# Altium schematic sheet XTIO.SchDoc
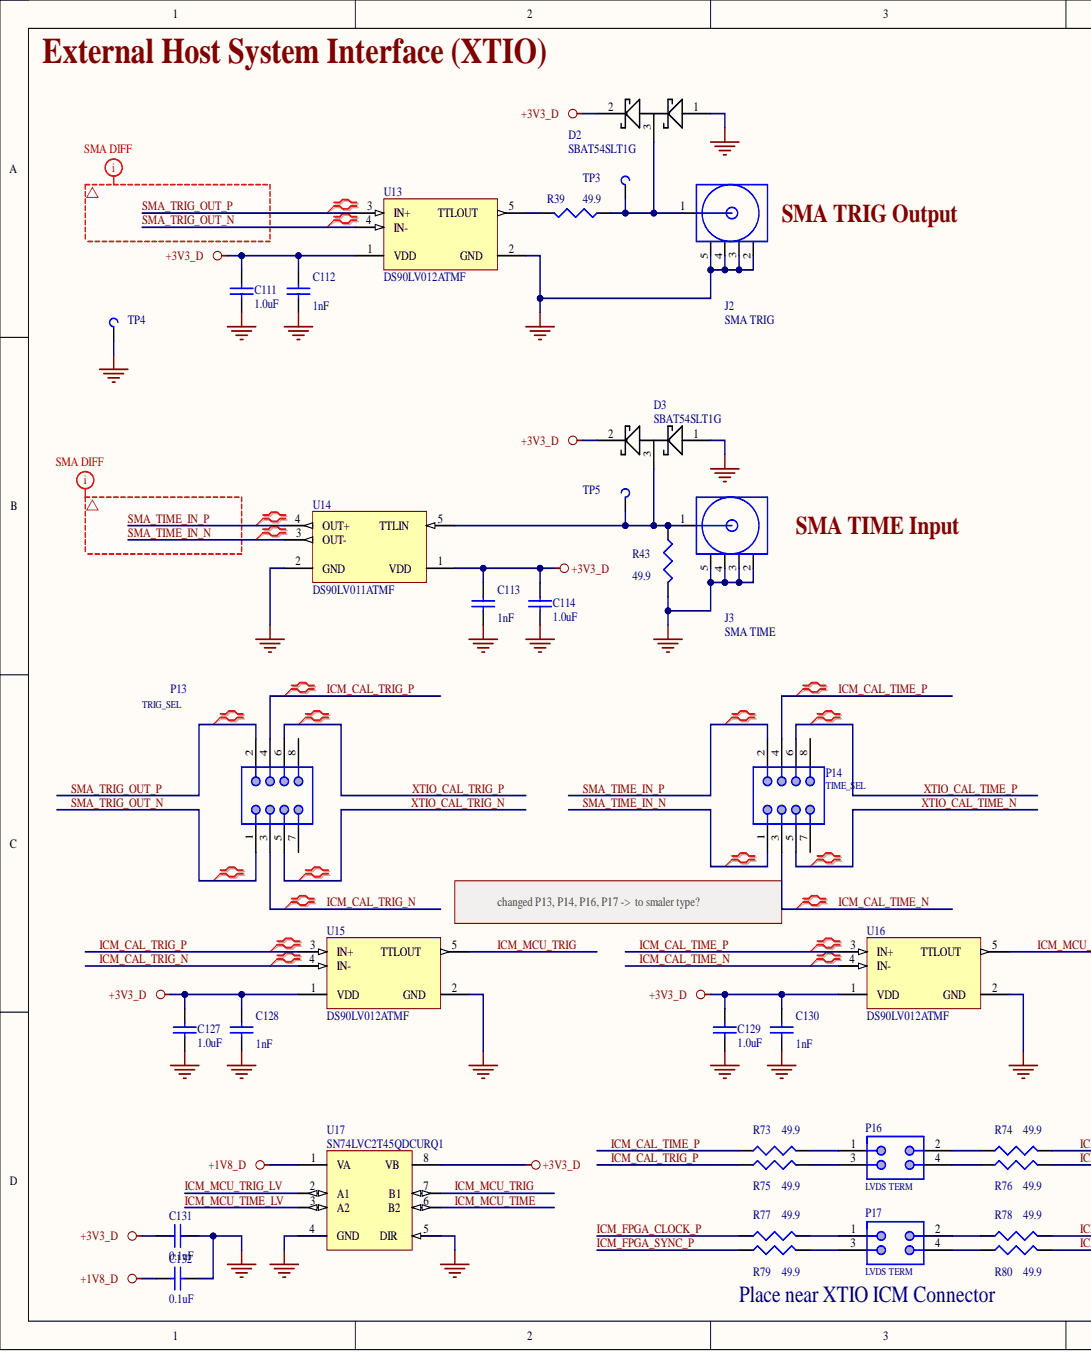
[diagram p0: XTIO.SchDoc, left]
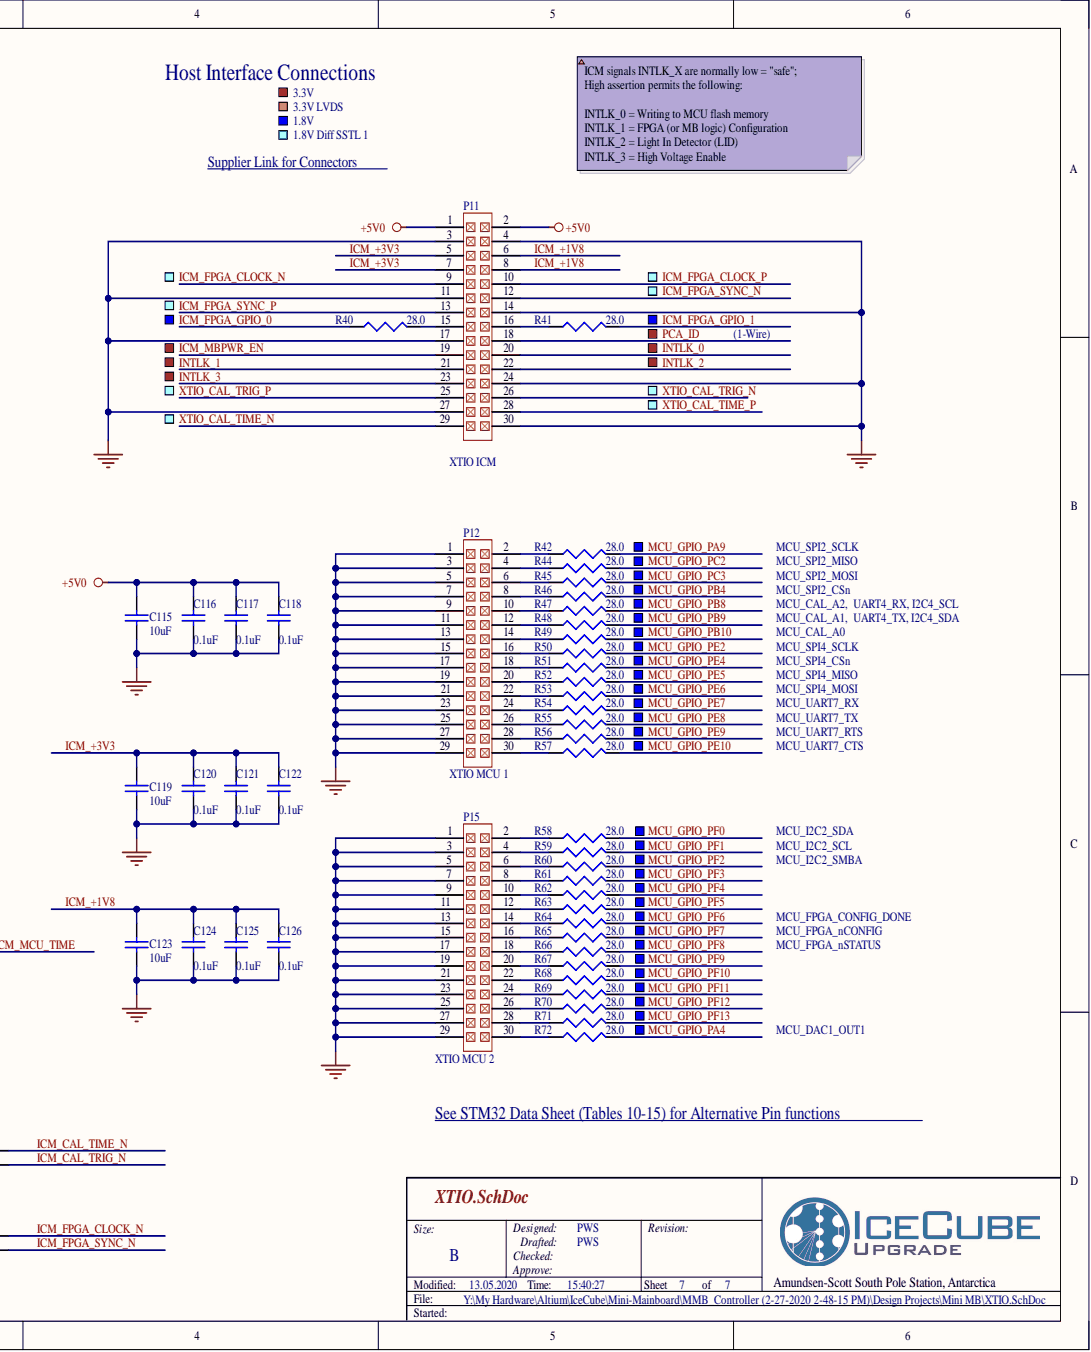
[diagram p1: XTIO.SchDoc, right]

## Parts
C111  Capacitance: 1.0uF  [CAPC_0603]  (hidden comment: 1.0uF_603_25V)
C112  Capacitance: 1nF  [CAPC_0603]  (hidden comment: 1000pF_603_50V)
C113  Capacitance: 1nF  [CAPC_0603]  (hidden comment: 1000pF_603_50V)
C114  Capacitance: 1.0uF  [CAPC_0603]  (hidden comment: 1.0uF_603_25V)
C115  Capacitance: 10uF  [CAPC_0805]  (hidden comment: 10uF_805_10V)
C116  Capacitance: 0.1uF  [CAPC_0603]  (hidden comment: 0.1uF_603_50V)
C117  Capacitance: 0.1uF  [CAPC_0603]  (hidden comment: 0.1uF_603_50V)
C118  Capacitance: 0.1uF  [CAPC_0603]  (hidden comment: 0.1uF_603_50V)
C119  Capacitance: 10uF  [CAPC_0805]  (hidden comment: 10uF_805_10V)
C120  Capacitance: 0.1uF  [CAPC_0603]  (hidden comment: 0.1uF_603_50V)
C121  Capacitance: 0.1uF  [CAPC_0603]  (hidden comment: 0.1uF_603_50V)
C122  Capacitance: 0.1uF  [CAPC_0603]  (hidden comment: 0.1uF_603_50V)
C123  Capacitance: 10uF  [CAPC_0805]  (hidden comment: 10uF_805_10V)
C124  Capacitance: 0.1uF  [CAPC_0603]  (hidden comment: 0.1uF_603_50V)
C125  Capacitance: 0.1uF  [CAPC_0603]  (hidden comment: 0.1uF_603_50V)
C126  Capacitance: 0.1uF  [CAPC_0603]  (hidden comment: 0.1uF_603_50V)
C127  Capacitance: 1.0uF  [CAPC_0603]  (hidden comment: 1.0uF_603_25V)
C128  Capacitance: 1nF  [CAPC_0603]  (hidden comment: 1000pF_603_50V)
C129  Capacitance: 1.0uF  [CAPC_0603]  (hidden comment: 1.0uF_603_25V)
C130  Capacitance: 1nF  [CAPC_0603]  (hidden comment: 1000pF_603_50V)
C131  Capacitance: 0.1uF  [CAPC_0603]  (hidden comment: 0.1uF_603_50V)
C132  Capacitance: 0.1uF  [CAPC_0603]  (hidden comment: 0.1uF_603_50V)
D2  SBAT54SLT1G  [SOT-23]
D3  SBAT54SLT1G  [SOT-23]
J2  SMA TRIG  Connector Style: SMA  [SMA Vertical 200LS]
J3  SMA TIME  Connector Style: SMA  [SMA Vertical 200LS]
P11  XTIO ICM  [45230-220230]
P12  XTIO MCU 1  [45230-220230]
P13  TRIG_SEL  [62100821021]
P14  TIME_SEL  [62100821021]
P15  XTIO MCU 2  [45230-220230]
P16  LVDS TERM  [62100421021]
P17  LVDS TERM  [62100421021]
R39  Resistance: 49.9  [RES_1206]  (hidden comment: R_49.9_1206_1%)
R40  Resistance: 28.0  [RES_0603]  (hidden comment: R_28.0_603_1%)
R41  Resistance: 28.0  [RES_0603]  (hidden comment: R_28.0_603_1%)
R42  Resistance: 28.0  [RES_0603]  (hidden comment: R_28.0_603_1%)
R43  Resistance: 49.9  [RES_1206]  (hidden comment: R_49.9_1206_1%)
R44  Resistance: 28.0  [RES_0603]  (hidden comment: R_28.0_603_1%)
R45  Resistance: 28.0  [RES_0603]  (hidden comment: R_28.0_603_1%)
R46  Resistance: 28.0  [RES_0603]  (hidden comment: R_28.0_603_1%)
R47  Resistance: 28.0  [RES_0603]  (hidden comment: R_28.0_603_1%)
R48  Resistance: 28.0  [RES_0603]  (hidden comment: R_28.0_603_1%)
R49  Resistance: 28.0  [RES_0603]  (hidden comment: R_28.0_603_1%)
R50  Resistance: 28.0  [RES_0603]  (hidden comment: R_28.0_603_1%)
R51  Resistance: 28.0  [RES_0603]  (hidden comment: R_28.0_603_1%)
R52  Resistance: 28.0  [RES_0603]  (hidden comment: R_28.0_603_1%)
R53  Resistance: 28.0  [RES_0603]  (hidden comment: R_28.0_603_1%)
R54  Resistance: 28.0  [RES_0603]  (hidden comment: R_28.0_603_1%)
R55  Resistance: 28.0  [RES_0603]  (hidden comment: R_28.0_603_1%)
R56  Resistance: 28.0  [RES_0603]  (hidden comment: R_28.0_603_1%)
R57  Resistance: 28.0  [RES_0603]  (hidden comment: R_28.0_603_1%)
R58  Resistance: 28.0  [RES_0603]  (hidden comment: R_28.0_603_1%)
R59  Resistance: 28.0  [RES_0603]  (hidden comment: R_28.0_603_1%)
R60  Resistance: 28.0  [RES_0603]  (hidden comment: R_28.0_603_1%)
R61  Resistance: 28.0  [RES_0603]  (hidden comment: R_28.0_603_1%)
R62  Resistance: 28.0  [RES_0603]  (hidden comment: R_28.0_603_1%)
R63  Resistance: 28.0  [RES_0603]  (hidden comment: R_28.0_603_1%)
R64  Resistance: 28.0  [RES_0603]  (hidden comment: R_28.0_603_1%)
R65  Resistance: 28.0  [RES_0603]  (hidden comment: R_28.0_603_1%)
R66  Resistance: 28.0  [RES_0603]  (hidden comment: R_28.0_603_1%)
R67  Resistance: 28.0  [RES_0603]  (hidden comment: R_28.0_603_1%)
R68  Resistance: 28.0  [RES_0603]  (hidden comment: R_28.0_603_1%)
R69  Resistance: 28.0  [RES_0603]  (hidden comment: R_28.0_603_1%)
R70  Resistance: 28.0  [RES_0603]  (hidden comment: R_28.0_603_1%)
R71  Resistance: 28.0  [RES_0603]  (hidden comment: R_28.0_603_1%)
R72  Resistance: 28.0  [RES_0603]  (hidden comment: R_28.0_603_1%)
R73  Resistance: 49.9  [RES_0603]  (hidden comment: R_49.9_603_1%)
R74  Resistance: 49.9  [RES_0603]  (hidden comment: R_49.9_603_1%)
R75  Resistance: 49.9  [RES_0603]  (hidden comment: R_49.9_603_1%)
R76  Resistance: 49.9  [RES_0603]  (hidden comment: R_49.9_603_1%)
R77  Resistance: 49.9  [RES_0603]  (hidden comment: R_49.9_603_1%)
R78  Resistance: 49.9  [RES_0603]  (hidden comment: R_49.9_603_1%)
R79  Resistance: 49.9  [RES_0603]  (hidden comment: R_49.9_603_1%)
R80  Resistance: 49.9  [RES_0603]  (hidden comment: R_49.9_603_1%)
TP3  PC TEST POINT MINI SMD  [Test Point SM]  (value not drawn)
TP4  PC TEST POINT MINI SMD  [Test Point SM]  (value not drawn)
TP5  PC TEST POINT MINI SMD  [Test Point SM]  (value not drawn)
U13  DS90LV012ATMF  [MF05A_N]
U14  DS90LV011ATMF  [MF05A_N]
U15  DS90LV012ATMF  [MF05A_N]
U16  DS90LV012ATMF  [MF05A_N]
U17  SN74LVC2T45QDCURQ1  [TI-DGK8_N]

## Nets
+1V8_D: C132.2 U17.1(VA)
+3V3_D: C111.2 C112.2 C113.2 C114.2 C127.2 C128.2 C129.2 C130.2 C131.2 D2.2 D3.2 U13.1(VDD) U14.1(VDD) U15.1(VDD) U16.1(VDD) U17.8(VB)
+5V0: C115.2 C116.2 C117.2 C118.2 P11.1 P11.2
GND: C111.1 C112.1 C113.1 C114.1 C115.1 C116.1 C117.1 C118.1 C119.1 C120.1 C121.1 C122.1 C123.1 C124.1 C125.1 C126.1 C127.1 C128.1 C129.1 C130.1 C131.1 C132.1 D2.1 D3.1 J2.2 J2.3 J2.4 J2.5 J3.2 J3.3 J3.4 J3.5 P11.3 P11.4 P11.11 P11.14 P11.17 P11.24 P11.27 P11.30 P12.1 P12.3 P12.5 P12.7 P12.9 P12.11 P12.13 P12.15 P12.17 P12.19 P12.21 P12.23 P12.25 P12.27 P12.29 P15.1 P15.3 P15.5 P15.7 P15.9 P15.11 P15.13 P15.15 P15.17 P15.19 P15.21 P15.23 P15.25 P15.27 P15.29 R43.1 TP4.1 U13.2(GND) U14.2(GND) U15.2(GND) U16.2(GND) U17.4(GND) U17.5(DIR)
ICM_+1V8: C123.2 C124.2 C125.2 C126.2 P11.6 P11.8
ICM_+3V3: C119.2 C120.2 C121.2 C122.2 P11.5 P11.7
ICM_CAL_TIME_N: P14.3 R74.2 U16.4(IN-)
ICM_CAL_TIME_P: P14.4 R73.1 U16.3(IN+)
ICM_CAL_TRIG_N: P13.3 R76.2 U15.4(IN-)
ICM_CAL_TRIG_P: P13.4 R75.1 U15.3(IN+)
ICM_FPGA_CLOCK_N: P11.9 R78.2
ICM_FPGA_CLOCK_P: P11.10 R77.1
ICM_FPGA_GPIO_0: R40.1
ICM_FPGA_GPIO_1: R41.2
ICM_FPGA_SYNC_N: P11.12 R80.2
ICM_FPGA_SYNC_P: P11.13 R79.1
ICM_MBPWR_EN: P11.19
ICM_MCU_TIME: U16.5(TTLOUT) U17.6(B2)
ICM_MCU_TIME_LV: U17.3(A2)
ICM_MCU_TRIG: U15.5(TTLOUT) U17.7(B1)
ICM_MCU_TRIG_LV: U17.2(A1)
INTLK_0: P11.20
INTLK_1: P11.21
INTLK_2: P11.22
INTLK_3: P11.23
MCU_GPIO_PA4: R72.2
MCU_GPIO_PA9: R42.2
MCU_GPIO_PB4: R46.2
MCU_GPIO_PB8: R47.2
MCU_GPIO_PB9: R48.2
MCU_GPIO_PB10: R49.2
MCU_GPIO_PC2: R44.2
MCU_GPIO_PC3: R45.2
MCU_GPIO_PE2: R50.2
MCU_GPIO_PE4: R51.2
MCU_GPIO_PE5: R52.2
MCU_GPIO_PE6: R53.2
MCU_GPIO_PE7: R54.2
MCU_GPIO_PE8: R55.2
MCU_GPIO_PE9: R56.2
MCU_GPIO_PE10: R57.2
MCU_GPIO_PF0: R58.2
MCU_GPIO_PF1: R59.2
MCU_GPIO_PF2: R60.2
MCU_GPIO_PF3: R61.2
MCU_GPIO_PF4: R62.2
MCU_GPIO_PF5: R63.2
MCU_GPIO_PF6: R64.2
MCU_GPIO_PF7: R65.2
MCU_GPIO_PF8: R66.2
MCU_GPIO_PF9: R67.2
MCU_GPIO_PF10: R68.2
MCU_GPIO_PF11: R69.2
MCU_GPIO_PF12: R70.2
MCU_GPIO_PF13: R71.2
PCA_ID: P11.18
SMA_TIME_IN_N: P14.1 U14.3(OUT-)
SMA_TIME_IN_P: P14.2 U14.4(OUT+)
SMA_TRIG_OUT_N: P13.1 U13.4(IN-)
SMA_TRIG_OUT_P: P13.2 U13.3(IN+)
XTIO_CAL_TIME_N: P11.29 P14.5
XTIO_CAL_TIME_P: P11.28 P14.6
XTIO_CAL_TRIG_N: P11.26 P13.5
XTIO_CAL_TRIG_P: P11.25 P13.6
NetD2_3: D2.3 J2.1 R39.1 TP3.1
NetD3_3: D3.3 J3.1 R43.2 TP5.1 U14.5(TTLIN)
NetP11_15: P11.15 R40.2
NetP11_16: P11.16 R41.1
NetP12_2: P12.2 R42.1
NetP12_4: P12.4 R44.1
NetP12_6: P12.6 R45.1
NetP12_8: P12.8 R46.1
NetP12_10: P12.10 R47.1
NetP12_12: P12.12 R48.1
NetP12_14: P12.14 R49.1
NetP12_16: P12.16 R50.1
NetP12_18: P12.18 R51.1
NetP12_20: P12.20 R52.1
NetP12_22: P12.22 R53.1
NetP12_24: P12.24 R54.1
NetP12_26: P12.26 R55.1
NetP12_28: P12.28 R56.1
NetP12_30: P12.30 R57.1
NetP15_2: P15.2 R58.1
NetP15_4: P15.4 R59.1
NetP15_6: P15.6 R60.1
NetP15_8: P15.8 R61.1
NetP15_10: P15.10 R62.1
NetP15_12: P15.12 R63.1
NetP15_14: P15.14 R64.1
NetP15_16: P15.16 R65.1
NetP15_18: P15.18 R66.1
NetP15_20: P15.20 R67.1
NetP15_22: P15.22 R68.1
NetP15_24: P15.24 R69.1
NetP15_26: P15.26 R70.1
NetP15_28: P15.28 R71.1
NetP15_30: P15.30 R72.1
NetP16_1: P16.1 R73.2
NetP16_2: P16.2 R74.1
NetP16_3: P16.3 R75.2
NetP16_4: P16.4 R76.1
NetP17_1: P17.1 R77.2
NetP17_2: P17.2 R78.1
NetP17_3: P17.3 R79.2
NetP17_4: P17.4 R80.1
NetR39_2: R39.2 U13.5(TTLOUT)

Not connected: P13 7 8; P14 7 8

## Library data of the parts
C111 C114 C127 C129 = CapC_1.0uF_603_25V: 1µF ±10% 25V Ceramic Capacitor X7R 0603 (1608 Metric); Manufacturer: Murata; Manufacturer Part Number: GCJ188R71E105KA01D; Case/Package: 0603; Component Kind: Standard; ComponentLink1Description: Supplier Link; ComponentLink1URL: https://www.digikey.com/products/en?keywords=490-14409-1-ND; ComponentLink2Description: Datasheet; ComponentLink2URL: https://search.murata.co.jp/Ceramy/image/img/A01X/G101/ENG/GCJ188R71E105KA01-01.pdf; ComponentLink3Description: Catalog; ComponentLink3URL: https://www.murata.com/~/media/webrenewal/support/library/catalog/products/capacitor/mlcc/c03e.ashx?la=en-us; Lead Free: Lead Free; Library Name: Custom1.SchLib; Pricing 1: 1=0.28000, 10=0.19400, 100=0.11020, 500=0.07780, 1000=0.06807 (USD); RoHS: No; Signal Integrity: Cap; Simulation: CAP; Stock 1: 166271; Supplier 1: Digi-Key; Supplier Part Number 1: 490-14409-1-ND
C112 C113 C128 C130 = CapC_1000pF_603_50V: Capacitor; Manufacturer: Knowles Syfer; Manufacturer Part Number: 0603Y0500102KXT; Dielectric: X7R; Dissipation Factor: 2.5%; Tolerance: 10%; Voltage Rating: 50V; Case Code (Imperial): 0603; Case Code (Metric): 1608; Case/Package: 0603; Component Kind: Standard; ComponentLink1Description: Datasheet; ComponentLink1URL: https://www.knowlescapacitors.com/getattachment/2dbfc8bc-e2b3-4b4d-afb1-43b011b9ebe0/High-Std-Voltage.aspx; ComponentLink2Description: Supplier Link; ComponentLink2URL: https://www.digikey.com/product-detail/en/knowles-syfer/0603Y0500102KXT/1608-1367-1-ND/7644469; Composition: Ceramic; Depth: 0.8mm; Height: 0.8mm; Length: 1.6mm; Library Name: Custom1.SchLib; Max Operating Temperature: 125°C; Min Operating Temperature: -55°C; Package Quantity: 4000; Packaging: Tape and Reel; Pricing 1: 1 = 0.540000, 10 = 0.379000, 100 = 0.249600, 500 = 0.184900, 1000 = 0.157160 (USD); RoHS: Yes; Signal Integrity: Cap; Simulation: CAP; Stock 1: 7150; Supplier 1: Digi-Key; Supplier Part Number 1: 1608-1367-1-ND; Termination: SMD/SMT; Voltage Rating (DC): 50V; Width: 0.8mm
C115 C119 C123 = CapC_10uF_805_10V: Capacitor; Manufacturer: Murata; Manufacturer Part Number: GCJ21BR71A106KE01L; Dielectric: X7R; Tolerance: 10%; Voltage Rating: 10V; Case Code (Imperial): 0805; Case Code (Metric): 2012; Case/Package: 0805; Component Kind: Standard; ComponentLink1Description: Datasheet; ComponentLink1URL: https://www.murata.com/~/media/webrenewal/support/library/catalog/products/capacitor/mlcc/c03e.ashx?la=en-us; ComponentLink2Description: Supplier Link; ComponentLink2URL: https://www.digikey.com/products/en?keywords=490-12646-1-ND; Depth: 1.25mm; Features: Soft Termination; Height: 1.25mm; Length: 2mm; Library Name: Custom1.SchLib; Max Operating Temperature: 125°C; Min Operating Temperature: -55°C; Mount: Surface Mount; Package Quantity: 3000; Packaging: Tape and Reel; Pricing 1: 1 = 0.70000, 10 = 0.49300, 100 = 0.32440, 500 = 0.24032, 1000 = 0.20428 (USD); Ratings: AEC-Q200; RoHS: Yes; Signal Integrity: Cap; Simulation: CAP; Stock 1: 140399; Supplier 1: Digi-Key; Supplier Part Number 1: 490-12646-1-ND; Termination: SMD/SMT; Thickness: 0.057inch; Voltage Rating (DC): 10V; Weight: 0.000335oz; Width: 0.049inch
C116-C118 C120-C122 C124-C126 = CapC_0.1uF_603_50V_GCJ: 0.1µF ±10% 50V Ceramic Capacitor X7R 0603 (1608 Metric); Manufacturer: Murata; Manufacturer Part Number: GCJ188R71H104KA12D; Case Code (Imperial): 0603; Case Code (Metric): 1608; Case/Package: 0603; Component Kind: Standard; ComponentLink1Description: Datasheet; ComponentLink1URL: https://psearch.en.murata.com/capacitor/product/GCJ188R71H104KA12%23.pdf; ComponentLink2Description: Supplier Link; ComponentLink2URL: https://www.digikey.com/products/en?keywords=490-5798-1-ND; Depth: 0.8mm; Dielectric: X7R; Features: Soft Termination; Height: 0.8mm; Lead Free: Lead Free; Length: 1.6mm; Library Name: Custom1.SchLib; Max Operating Temperature: 125°C; Min Operating Temperature: -55°C; Mount: Surface Mount; Package Quantity: 1; Packaging: Tape and Reel; Pricing 1: 1=0.28000, 10=0.19200, 100=0.10890, 500=0.07686, 1000=0.06725 (USD); Radiation Hardening: No; Ratings: AEC-Q200; RoHS: Yes; Signal Integrity: Cap; Simulation: CAP; Stock 1: 2321609; Supplier 1: Digi-Key; Supplier Part Number 1: 490-5798-1-ND; Termination: SMD/SMT; Thickness: 0.035inch; Tolerance: 10%; Voltage Rating: 50V; Voltage Rating (DC): 50V; Width: 0.031inch
C131 C132 = CapC_0.1uF_603_50V: 0.1µF ±10% 50V Ceramic Capacitor X7R 0603 (1608 Metric); Manufacturer: TDK; Manufacturer Part Number: CGJ3E2X7R1H104K080AA; Ratings: COTS; Case Code (Imperial): 0603; Case Code (Metric): 1608; Case/Package: 0603; Component Kind: Standard; ComponentLink1Description: Datasheet; ComponentLink1URL: https://product.tdk.com/info/en/catalog/datasheets/mlcc_highreliability_general_en.pdf; ComponentLink2Description: Supplier Link; ComponentLink2URL: https://www.digikey.com/products/en?keywords=445-8122-1-ND; Composition: Ceramic; Depth: 0.8mm; Dielectric: X7R; Height: 0.8mm; Lead Free: Lead Free; Length: 1.6mm; Library Name: Custom1.SchLib; Max Operating Temperature: 125°C; Min Operating Temperature: -55°C; Mount: Surface Mount; Package Quantity: 1; Packaging: Tape and Reel; Pricing 1: 1 = 0.22000, 10 = 0.15300, 100 = 0.07740, 500 = 0.05294, 1000 = 0.04683 (USD); Radiation Hardening: No; RoHS: Yes; Signal Integrity: Cap; Simulation: CAP; Stock 1: 697211; Supplier 1: Digi-Key; Supplier Part Number 1: 445-8122-1-ND; Termination: SMD/SMT; Thickness: 0.035inch; Tolerance: 10%; Voltage Rating: 50V; Voltage Rating (DC): 50V; Weight: 7.1E-05oz; Width: 0.8mm
D2 D3 = Diode ESD Pair: DIODE ARRAY SCHOTTKY 30V SOT23-3; Manufacturer: ON Semiconductor; Average Rectified Current: 200mA; Element Configuration: Dual; Forward Voltage: 800mV; Max Reverse Leakage Current: 2uA; Max Surge Current: 600mA; Power Dissipation: 225mW; Recovery Time: 5ns; Case/Package: SOT-23-3; Component Kind: Standard; ComponentLink1Description: Supplier Link; ComponentLink1URL: https://www.digikey.com/products/en?keywords=SBAT54SLT1GOSCT-ND; ComponentLink2Description: Datasheet; ComponentLink2URL: http://www.onsemi.com/pub/Collateral/BAT54SLT1-D.PDF; Forward Current: 200mA; Halogen Free: Halogen Free; Height: 1.01mm; Lead Free: Lead Free; Length: 3.04mm; Library Name: Custom1.SchLib; Manufacturer Part Number: SBAT54SLT1G; Max Operating Temperature: 150°C; Max Repetitive Reverse Voltage (Vrrm): 30V; Max Reverse Voltage (DC): 30V; Min Operating Temperature: -55°C; Number of Pins: 3; Package Quantity: 3000; Packaging: Tape and Reel; Peak Non-Repetitive Surge Current: 600mA; Peak Reverse Current: 2uA; Pricing 1: 1 = 0.35000, 10 = 0.28800, 100 = 0.19580, 500 = 0.14684, 1000 = 0.11012 (USD); Radiation Hardening: No; Reverse Recovery Time: 5ns; RoHS: Yes; Stock 1: 119322; Supplier 1: Digi-Key; Supplier Part Number 1: SBAT54SLT1GOSCT-ND; Width: 1.4mm
J2 J3 = SMA-Vertical: SMA Vertical, 0.200"LS; Manufacturer: Emerson Network Power Connectivity Johnson; Manufacturer Part Number: 142-0701-231; Body Finish: Gold; Body Material: Brass; Center Contact Material: Beryllium Copper; Connector Type: Jack, Female Socket; Contact Termination: Solder; Dielectric Material: Polytetrafluoroethylene (PTFE); Frequency - Max: 18GHz; Impedance: 50 Ohm; Voltage Rating: 335V; Cable Group: -; Category: Connectors, Interconnects; Center Contact Plating: Gold; Component Kind: Standard; ComponentLink1Description: https://cinchconnectivity.com/OA_MEDIA/specs/pi-142-0701-231.pdf; ComponentLink1URL: https://cinchconnectivity.com/OA_MEDIA/specs/pi-142-0701-231.pdf; Fastening Type: Threaded; Features: -; Housing Color: Gold; Ingress Protection: -; Library Name: Custom1.SchLib; Mounting Type: Through Hole; Operating Temperature: -65°C ~ 165°C; Packaging: Bulk; Pricing: 1=5.46, 10=4.861, 50=3.968, 100=3.5712, 250=3.2736, 500=2.7776, 750=2.63872, 1000=2.48, 2500=2.3808 (USD); Pricing 1: 1=5.46, 10=4.861, 50=3.968, 100=3.5712, 250=3.2736, 500=2.7776, 750=2.63872, 1000=2.48, 2500=2.3808 (USD); RoHS: RoHS Compliant; Shield Termination: Solder; Stock: 9668; Stock 1: 9535; Supplier 1: Digi-Key; Supplier Part Number 1: J608-ND
P11 P12 P15 = Header Male 3M-452 15x2: CONN HEADER SMD 30POS 1.27MM; Manufacturer: 3M; Connector Type: Male Pin; Current Rating: 1A; Number of Contacts: 30; Row Spacing: 0.05inch; Voltage Rating: 125V; Component Kind: Standard; ComponentLink1Description: Supplier Link; ComponentLink1URL: https://www.digikey.com/products/en?keywords=3M15579CT-ND; ComponentLink2Description: Datasheet; ComponentLink2URL: https://media.digikey.com/pdf/Data%20Sheets/3M%20PDFs/451,452_Series_Header&Socket.pdf; Contact Gender: Male; Contact Material: Copper Alloy,Bronze; Contact Plating: Gold; Fastening Type: Latch; Flammability Rating: UL94 V-0; Housing Material: Liquid Crystal Polymer; Library Name: Custom1.SchLib; Manufacturer Part Number: 45230-220230; Max Operating Temperature: 105°C; Min Operating Temperature: -55°C; Mount: Surface Mount; Number of Positions: 30; Number of Rows: 2; Package Quantity: 500; Packaging: Cut Tape; Pitch: 1.27mm; Pricing 1: 1 = 10.50000, 10 = 10.04800, 100 = 8.44930 (USD); RoHS: Yes; Stock 1: 334; Supplier 1: Digi-Key; Supplier Part Number 1: 3M15579CT-ND; Termination: Solder
P13 P14 = 62100821021: WR-PHD Pin Header, SMT, pitch 1.27mm, Dual Row, Vertical, 8pin; Contact Resistance: 20mOhm; Gender: Male; Pin Number: 8; Rated Current: 1A; Withstanding Voltage: 500VAC; Working Voltage: 100VAC; Component Kind: Standard; ComponentLink1Description: Manufacturer; ComponentLink1URL: http://www.we-online.de; ComponentLink2Description: Datasheet; ComponentLink2URL: https://katalog.we-online.de/em/datasheet/62100821021.pdf; Library Name: WR-PHD.IntLib; Mounting Technology: SMT; Operating Temperature: -40 to +105degC; Orientation: Vertical; Packaging: Tube; Part Number: 62100821021; Pitch: 1.27mm; Published Date: June 2019; Row Number: Dual; Publisher: Wurth Elektronik
P16 P17 = 62100421021: WR-PHD Pin Header, SMT, pitch 1.27mm, Dual Row, Vertical, 4pin; Contact Resistance: 20mOhm; Gender: Male; Pin Number: 4; Rated Current: 1A; Withstanding Voltage: 500VAC; Working Voltage: 100VAC; Component Kind: Standard; ComponentLink1Description: Manufacturer; ComponentLink1URL: http://www.we-online.de; ComponentLink2Description: Datasheet; ComponentLink2URL: https://katalog.we-online.de/em/datasheet/62100421021.pdf; Library Name: WR-PHD.IntLib; Mounting Technology: SMT; Operating Temperature: -40 to +105degC; Orientation: Vertical; Packaging: Tube; Part Number: 62100421021; Pitch: 1.27mm; Published Date: June 2019; Row Number: Dual; Publisher: Wurth Elektronik
R39 R43 = R_49.9_1206_1%: RES 50 OHM 1/2W 1% 1206 SMD; Manufacturer: Panasonic; Manufacturer Part Number: ERJ8ENF49R9V; Max Power Dissipation: 250mW; Temperature Coefficient: 100ppm/°C; Voltage Rating: 200V; Case Code (Imperial): 1206; Case Code (Metric): 3216; Case/Package: 1206; Component Kind: Standard; ComponentLink1Description: http://www.vishay.com/docs/20043/crcwhpe3.pdf; ComponentLink1URL: http://www.vishay.com/docs/20043/crcwhpe3.pdf; ComponentLink2Description: https://datasheet.ciiva.com/30178/aoa0000ce2-30178133.pdf?src-supplier=Onlinecomponents.com; ComponentLink2URL: https://datasheet.ciiva.com/30178/aoa0000ce2-30178133.pdf?src-supplier=Onlinecomponents.com; ComponentLink3Description: https://datasheet.ciiva.com/43460/426256-43460149.pdf?src-supplier=Farnell; ComponentLink3URL: https://datasheet.ciiva.com/43460/426256-43460149.pdf?src-supplier=Farnell; ComponentLink4Description: https://datasheet.ciiva.com/46377/426256-46377239.pdf?src-supplier=Element14; ComponentLink4URL: https://datasheet.ciiva.com/46377/426256-46377239.pdf?src-supplier=Element14; ComponentLink5Description: https://datasheet.ciiva.com/24027/aoa0000ce2-24027304.pdf?src-supplier=Mouser; ComponentLink5URL: https://datasheet.ciiva.com/24027/aoa0000ce2-24027304.pdf?src-supplier=Mouser; ComponentLink6Description: https://datasheet.ciiva.com/46388/426256-46388904.pdf?src-supplier=Element14; ComponentLink6URL: https://datasheet.ciiva.com/46388/426256-46388904.pdf?src-supplier=Element14; ComponentLink7Description: https://datasheet.ciiva.com/6159/426256-6159641.pdf?src-supplier=Farnell; ComponentLink7URL: https://datasheet.ciiva.com/6159/426256-6159641.pdf?src-supplier=Farnell; ComponentLink8Description: https://datasheet.ciiva.com/27055/1990245-27055770.pdf?src-supplier=Jameco; ComponentLink8URL: https://datasheet.ciiva.com/27055/1990245-27055770.pdf?src-supplier=Jameco; ComponentLink9Description: https://datasheet.ciiva.com/16725/70260748-16725115.pdf?src-supplier=Allied+Electronics; ComponentLink9URL: https://datasheet.ciiva.com/16725/70260748-16725115.pdf?src-supplier=Allied+Electronics; ComponentLink10Description: https://datasheet.ciiva.com/28694/1678782-28694252.pdf?src-supplier=Element14; ComponentLink10URL: https://datasheet.ciiva.com/28694/1678782-28694252.pdf?src-supplier=Element14; ComponentLink11Description: https://datasheet.ciiva.com/16785/70260748-16785263.pdf?src-supplier=Allied+Electronics; ComponentLink11URL: https://datasheet.ciiva.com/16785/70260748-16785263.pdf?src-supplier=Allied+Electronics; ComponentLink12Description: https://datasheet.ciiva.com/17209/aoa0000ce2-17209487.pdf?src-supplier=Avnet+Europe; ComponentLink12URL: https://datasheet.ciiva.com/17209/aoa0000ce2-17209487.pdf?src-supplier=Avnet+Europe; Composition: Thick Film; Contact Plating: Tin; Depth: 1.6mm; Features: Automotive AEC-Q200; Height: 0.6mm; Lead Free: Lead Free; Length: 3.2mm; Library Name: Custom1.SchLib; Max Operating Temperature: 155°C; Military Standard: Not; Min Operating Temperature: -55°C; Mount: Surface Mount; Number of Terminations: 2; Package Quantity: 1; Packaging: Tape and Reel; Power Rating: 250mW; Pricing 1: 1 = 0.11000, 10 = 0.10000, 100 = 0.03850, 1000 = 0.01695, 2500 = 0.01464 (USD); Radiation Hardening: No; REACH SVHC: Unknown; RoHS: Yes; Signal Integrity: Res; Simulation: RESISTOR; Stock 1: 167353; Supplier 1: Digi-Key; Supplier Part Number 1: P49.9FCT-ND; Termination: SMD/SMT; Tolerance: 1%; Voltage Rating (DC): 200V; Width: 0.063inch; Working Voltage: 200V
R40-R42 R44-R72 = R_28.0_603_1%: RES 28.0 OHM 1/10W 1% 0603 SMD; Manufacturer: Panasonic; Manufacturer Part Number: ERJ3EKF28R0V; Max Power Dissipation: 100mW; Temperature Coefficient: 100ppm/°C; Voltage Rating: 75V; Voltage Rating (DC): 50V; Case Code (Imperial): 0603; Case Code (Metric): 1608; Case/Package: 0603; Component Kind: Standard; ComponentLink1Description: https://datasheet.ciiva.com/46437/1678782-46437594.pdf?src-supplier=Element14; ComponentLink1URL: https://datasheet.ciiva.com/46437/1678782-46437594.pdf?src-supplier=Element14; ComponentLink2Description: https://datasheet.ciiva.com/22676/1678782-22676667.pdf?src-supplier=Element14; ComponentLink2URL: https://datasheet.ciiva.com/22676/1678782-22676667.pdf?src-supplier=Element14; ComponentLink3Description: https://datasheet.ciiva.com/22736/1678782-22736555.pdf?src-supplier=Element14; ComponentLink3URL: https://datasheet.ciiva.com/22736/1678782-22736555.pdf?src-supplier=Element14; Composition: Thick Film; Contact Plating: Tin; Features: Automotive AEC-Q200; Height: 0.022inch; Lead Free: Lead Free; Length: 1.6mm; Library Name: Custom1.SchLib; Max Operating Temperature: 155°C; Min Operating Temperature: -55°C; Mount: Surface Mount; Number of Terminations: 2; Package Quantity: 1; Packaging: Tape and Reel; Power Rating: 100mW; Pricing 1: 1 = 0.10000, 10 = 0.06500, 100 = 0.02640, 1000 = 0.01186, 2500 = 0.01029 (USD); Radiation Hardening: No; REACH SVHC: Yes; RoHS: Yes; Signal Integrity: Res; Simulation: RESISTOR; Stock 1: 75130; Supplier 1: Digi-Key; Supplier Part Number 1: P28.0HCT-ND; Tolerance: 1%; Width: 0.8mm
R73-R80 = R_49.9_603_1%: RES 49.9 OHM 1/10W 1% 0603 SMD; Manufacturer: Panasonic; Manufacturer Part Number: ERJ3EKF49R9V; Max Power Dissipation: 100mW; Temperature Coefficient: 100ppm/°C; Voltage Rating: 75V; Voltage Rating (DC): 50V; Working Voltage: 6V; Case Code (Imperial): 0603; Case Code (Metric): 1608; Case/Package: 0603; Component Kind: Standard; ComponentLink1Description: https://datasheet.ciiva.com/16766/70260748-16766262.pdf?src-supplier=Allied+Electronics; ComponentLink1URL: https://datasheet.ciiva.com/16766/70260748-16766262.pdf?src-supplier=Allied+Electronics; ComponentLink2Description: https://datasheet.ciiva.com/9117/aoa0000ce2-9117128.pdf?src-supplier=Avnet; ComponentLink2URL: https://datasheet.ciiva.com/9117/aoa0000ce2-9117128.pdf?src-supplier=Avnet; ComponentLink3Description: https://datasheet.ciiva.com/16772/70260748-16772570.pdf?src-supplier=Allied+Electronics; ComponentLink3URL: https://datasheet.ciiva.com/16772/70260748-16772570.pdf?src-supplier=Allied+Electronics; ComponentLink4Description: https://datasheet.ciiva.com/28694/1678782-28694219.pdf?src-supplier=Element14; ComponentLink4URL: https://datasheet.ciiva.com/28694/1678782-28694219.pdf?src-supplier=Element14; ComponentLink5Description: https://datasheet.ciiva.com/30095/aoa0000ce2-30095355.pdf?src-supplier=Onlinecomponents.com; ComponentLink5URL: https://datasheet.ciiva.com/30095/aoa0000ce2-30095355.pdf?src-supplier=Onlinecomponents.com; ComponentLink6Description: https://datasheet.ciiva.com/48531/aoa0000ce2-48531019.pdf?src-supplier=Avnet; ComponentLink6URL: https://datasheet.ciiva.com/48531/aoa0000ce2-48531019.pdf?src-supplier=Avnet; ComponentLink7Description: https://datasheet.ciiva.com/46388/1678782-46388908.pdf?src-supplier=Element14; ComponentLink7URL: https://datasheet.ciiva.com/46388/1678782-46388908.pdf?src-supplier=Element14; Composition: Thick Film; Contact Plating: Tin; Depth: 0.8mm; Features: Automotive AEC-Q200; Height: 0.022inch; Lead Free: Lead Free; Length: 1.6mm; Library Name: Custom1.SchLib; Max Operating Temperature: 155°C; Military Standard: Not; Min Operating Temperature: -55°C; Mount: Surface Mount; Number of Terminations: 2; Package Quantity: 1; Packaging: Tape and Reel; Power Rating: 100mW; Pricing 1: 1 = 0.100000, 10 = 0.065000, 100 = 0.026400, 1000 = 0.011860, 2500 = 0.010290 (USD); Radiation Hardening: No; REACH SVHC: Unknown; RoHS: Yes; Signal Integrity: Res; Simulation: RESISTOR; Stock 1: 2435501; Supplier 1: Digi-Key; Supplier Part Number 1: P49.9HCT-ND; Termination: SMD/SMT; Tolerance: 1%; Width: 0.8mm
TP3-TP5 = Test Point SM: 5019KCT-ND; Manufacturer: Keystone Electronics; Manufacturer Part Number: 5019; Category: Test and Measurement; Color: -; Component Kind: Standard; ComponentLink1Description: http://www.keyelco.com/product-pdf.cfm?p=1357; ComponentLink1URL: http://www.keyelco.com/product-pdf.cfm?p=1357; Library Name: Custom1.SchLib; Material: Phosphor Bronze; Mounting Type: Surface Mount; Packaging: Cut Tape (CT); Pricing: 1=0.3, 10=0.287, 50=0.2092, 100=0.201, 250=0.18048, 500=0.17228 (USD); RoHS: RoHS Compliant; Stock: 10485; Supplier 1: Digi-Key; Supplier Part Number 1: 5019KCT-ND; Terminal: 0.015" (0.38mm) Phosphor Bronze, Silver Plated; Type: PC Test Point, Miniature
U13 U15 U16 = DS90LV012ATMF: 3V LVDS Single CMOS Differential Line Receiver, 5-pin SOT-23; Manufacturer: TI National Semiconductor; Manufacturer Part Number: DS90LV012ATMF/NOPB; Data Rate: 400Mbps; Max Power Dissipation: 2.26W; Max Supply Current: 9mA; Max Supply Voltage: 3.6V; Min Supply Voltage: 2.7V; Number of Channels: 1; Operating Supply Voltage: 3.3V; Power Consumption: 18W; Propagation Delay: 3.5ns; Case/Package: SOT-23; Component Kind: Standard; ComponentLink1Description: http://www.ti.com/lit/ds/symlink/ds90lv012a.pdf; ComponentLink1URL: http://www.ti.com/lit/ds/symlink/ds90lv012a.pdf; ComponentLink2Description: https://datasheet.ciiva.com/15133/getdatasheetpartid-627853-15133191.pdf?src-supplier=Verical; ComponentLink2URL: https://datasheet.ciiva.com/15133/getdatasheetpartid-627853-15133191.pdf?src-supplier=Verical; ComponentLink3Description: https://datasheet.ciiva.com/41460/001187784-da-01-en-ic-line-ds90lv012atmf-nopb-sot-23-5-tid-41460741.pdf?src-supplier=Conrad; ComponentLink3URL: https://datasheet.ciiva.com/41460/001187784-da-01-en-ic-line-ds90lv012atmf-nopb-sot-23-5-tid-41460741.pdf?src-supplier=Conrad; ComponentLink4Description: https://datasheet.ciiva.com/1709/ds90lt012a-1709907.pdf?src-supplier=Digi-Key; ComponentLink4URL: https://datasheet.ciiva.com/1709/ds90lt012a-1709907.pdf?src-supplier=Digi-Key; ComponentLink5Description: https://datasheet.ciiva.com/3151/ds90lv012a-3151479.pdf?src-supplier=Avnet; ComponentLink5URL: https://datasheet.ciiva.com/3151/ds90lv012a-3151479.pdf?src-supplier=Avnet; ComponentLink6Description: https://datasheet.ciiva.com/1554/1849915-1554728.pdf?src-supplier=Farnell; ComponentLink6URL: https://datasheet.ciiva.com/1554/1849915-1554728.pdf?src-supplier=Farnell; ComponentLink7Description: https://datasheet.ciiva.com/3151/ds90lv012a-3151480.pdf?src-supplier=Avnet; ComponentLink7URL: https://datasheet.ciiva.com/3151/ds90lv012a-3151480.pdf?src-supplier=Avnet; ComponentLink8Description: https://datasheet.ciiva.com/1709/ds90lt012a-1709945.pdf?src-supplier=Digi-Key; ComponentLink8URL: https://datasheet.ciiva.com/1709/ds90lt012a-1709945.pdf?src-supplier=Digi-Key; ComponentLink9Description: https://datasheet.ciiva.com/1709/ds90lt012a-1709946.pdf?src-supplier=Digi-Key; ComponentLink9URL: https://datasheet.ciiva.com/1709/ds90lt012a-1709946.pdf?src-supplier=Digi-Key; Height: 1.02mm; Lead Free: Lead Free; Length: 2.92mm; Library Name: Custom1.SchLib; Max Operating Temperature: 85°C; Min Operating Temperature: -40°C; Mount: Surface Mount; Number of Drivers: 1; Number of Elements: 1; Number of Pins: 5; Number of Receivers: 1; Package Quantity: 1000; Packaging: Tape and Reel; Power Dissipation: 2.26W; Pricing 1: 1=1.05000, 10=0.93600, 100=0.72970, 500=0.60276 (USD); Radiation Hardening: No; REACH SVHC: No SVHC; RoHS: Yes; Stock 1: 46821; Supplier 1: Digi-Key; Supplier Part Number 1: DS90LV012ATMF/NOPBCT-ND; Turn-On Delay Time: 3.5ns; Width: 1.6mm
U14 = DS90LV011ATMF: Single High Speed Differential Driver, 5-pin SOT-23; Manufacturer: Texas Instruments; Manufacturer Part Number: DS90LV011ATMF/NOPB; Data Rate: 400Mbps; Interface: LVDS; Max Output Current: -24mA; Max Output Voltage: 450mV; Max Power Dissipation: 902mW; Max Supply Current: 10mA; Max Supply Voltage: 3.6V; Min Supply Voltage: 3V; Nominal Supply Current: 7mA; Number of Channels: 1; Operating Supply Voltage: 3.3V; Power Consumption: 17W; Propagation Delay: 1.5ns; Case/Package: SOT-23; Component Kind: Standard; ComponentLink1Description: http://www.ti.com/lit/ds/symlink/ds90lv011a.pdf; ComponentLink1URL: http://www.ti.com/lit/ds/symlink/ds90lv011a.pdf; ComponentLink2Description: https://datasheet.ciiva.com/46381/1581201-46381879.pdf?src-supplier=Element14; ComponentLink2URL: https://datasheet.ciiva.com/46381/1581201-46381879.pdf?src-supplier=Element14; ComponentLink3Description: https://datasheet.ciiva.com/46381/1882279-46381880.pdf?src-supplier=Element14; ComponentLink3URL: https://datasheet.ciiva.com/46381/1882279-46381880.pdf?src-supplier=Element14; Height: 1.02mm; Lead Free: Lead Free; Length: 2.92mm; Library Name: Custom1.SchLib; Max Operating Temperature: 85°C; Min Operating Temperature: -40°C; Mount: Surface Mount; Number of Drivers: 1; Number of Elements: 1; Number of Pins: 5; Number of Receivers: 1; Operating Supply Current: 10mA; Package Quantity: 1000; Packaging: Tape and Reel; Power Dissipation: 902mW; Pricing 1: 1 = 1.26000, 10 = 1.12400, 100 = 0.87650, 500 = 0.72410 (USD); Radiation Hardening: No; REACH SVHC: No SVHC; RoHS: Yes; Stock 1: 57010; Supplier 1: Digi-Key; Supplier Part Number 1: DS90LV011ATMF/NOPBCT-ND; Termination: SMD/SMT; Turn-On Delay Time: 1.5ns; Weight: 0.00709oz; Width: 1.6mm
U17 = SN74LVC2T45QDCURQ1: Voltage Level Translator Bidirectional 1 Circuit 2 Channel 420Mbps SM8 (SSOP); Generic Part Number: SN74LVC2T45-Q1; Manufacturer: Texas Instruments; Manufacturer Part Number: SN74LVC2T45MDCTTEP; 3-State Output: Yes; Bits(#): 2; F @ Nom Voltage(Max)(Mhz): 150; High Level Output Current: -32mA; ICC @ Nom Voltage(Max)(mA): 0.004; Input Type: CMOS/TTL; Logic: True; Low Level Output Current: 32mA; Max Supply Voltage: 5.5V; Min Supply Voltage: 1.65V; Number of Elements: 1; Output Drive (IOL/IOH)(Max)(mA): 32/-32; Output Type: CMOS; Polarity: Non-Inverting; Propagation Delay: 3.5ns; Quiescent Current: 12mA; Rating: Automotive; Schmitt Trigger: No; Sub-Family: Dual Supply Translator; Technology Family: LVC; tpd @ Nom Voltage(Max)(ns): 19.5,12,9.6,8.4; Turn-On Delay Time: 53.2ns; VCC(Max)(V): 5.5; VCC(Min)(V): 1.65; Voltage(Nom)(V): 1.8,2.5,3.3,5; Case/Package: SSOP; Component Kind: Standard; ComponentLink1Description: Manufacturer URL; ComponentLink1URL: http://www.ti.com/; ComponentLink2Description: Datasheet; ComponentLink2URL: http://www.ti.com/lit/ds/symlink/sn74lvc2t45-q1.pdf; ComponentLink3Description: https://datasheet.ciiva.com/14316/sn74lvc2t45-ep-14316386.pdf?src-supplier=Avnet; ComponentLink3URL: https://datasheet.ciiva.com/14316/sn74lvc2t45-ep-14316386.pdf?src-supplier=Avnet; ComponentLink4Description: https://datasheet.ciiva.com/15086/getdatasheetpartid-144548-15086248.pdf?src-supplier=Verical; ComponentLink4URL: https://datasheet.ciiva.com/15086/getdatasheetpartid-144548-15086248.pdf?src-supplier=Verical; ComponentLink5Description: https://datasheet.ciiva.com/1899/sn74lvc2t45-ep-1899619.pdf?src-supplier=Digi-Key; ComponentLink5URL: https://datasheet.ciiva.com/1899/sn74lvc2t45-ep-1899619.pdf?src-supplier=Digi-Key; ComponentLink6Description: https://datasheet.ciiva.com/1899/sn74lvc2t45-ep-1899620.pdf?src-supplier=Digi-Key; ComponentLink6URL: https://datasheet.ciiva.com/1899/sn74lvc2t45-ep-1899620.pdf?src-supplier=Digi-Key; ComponentLink7Description: https://datasheet.ciiva.com/1899/sn74lvc2t45-ep-1899621.pdf?src-supplier=Digi-Key; ComponentLink7URL: https://datasheet.ciiva.com/1899/sn74lvc2t45-ep-1899621.pdf?src-supplier=Digi-Key; ComponentLink8Description: https://datasheet.ciiva.com/48701/001073867-da-01-en-ic-bus-trans-sn74lvc2t45mdcttep-sm-8-tid-48701957.pdf?src-supplier=Conrad; ComponentLink8URL: https://datasheet.ciiva.com/48701/001073867-da-01-en-ic-bus-trans-sn74lvc2t45mdcttep-sm-8-tid-48701957.pdf?src-supplier=Conrad; Contact Plating: Gold; Data Rate: 420Mbps; DatasheetVersion: SCES818; Direction: Bidirectional; Lead Free: Lead Free; Library Name: Custom1.SchLib; Logic Function: Translator; Max Operating Temperature: 125°C; Min Operating Temperature: -55°C; Mount: Surface Mount; Number of Bits: 2; Number of Channels: 2; Number of Inputs: 2; Number of Pins: 8; Operating Temperature Range(C): -40 to 125; Output Current: 32mA; Package Quantity: 250; PackageReference: DCU0008A; Packaging: Tape and Reel; PartNumber: SN74LVC2T45QDCURQ1; Pricing 1: 1 = 1.67000, 10 = 1.50100, 100 = 1.20660 (USD); Radiation Hardening: No; REACH SVHC: No SVHC; RoHS: Yes; Stock 1: 5778; Supplier 1: Digi-Key; Supplier Part Number 1: 296-23952-1-ND; Weight: 0.000825oz
